# Altium schematic sheet TS5_MCU.SchDoc(TS5_MCU)
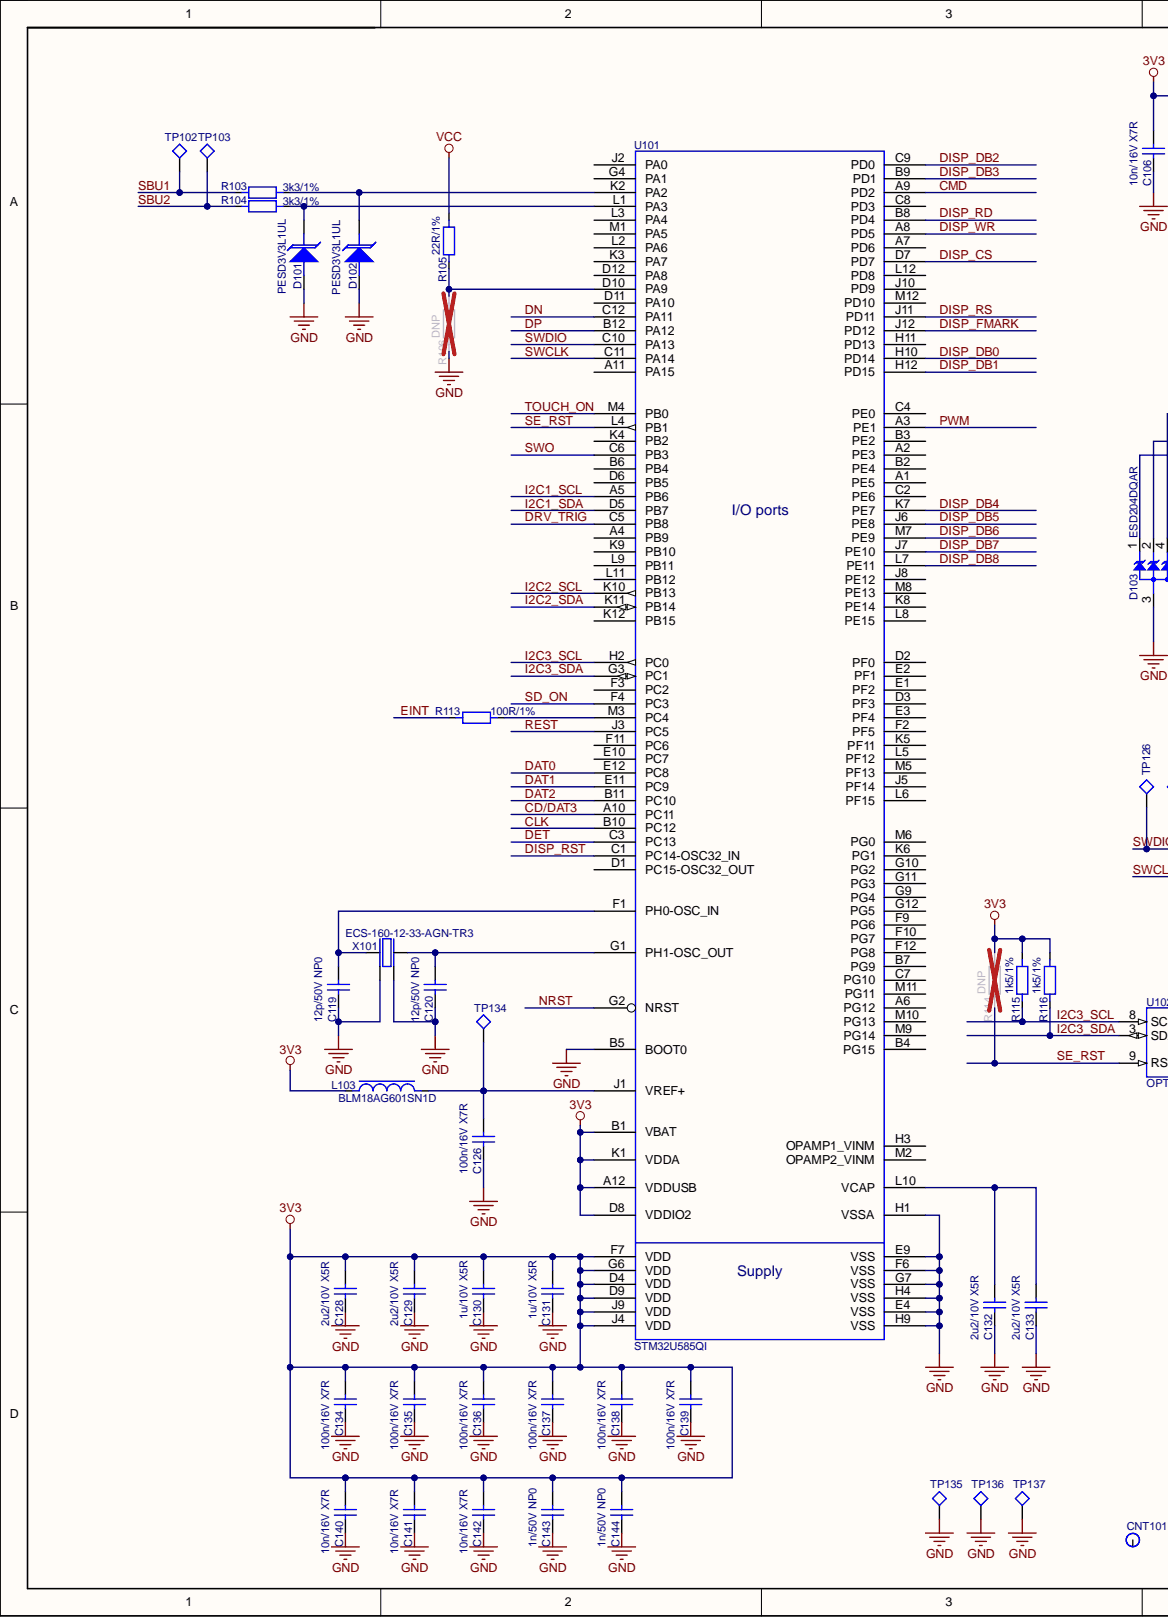
[diagram p0: TS5_MCU.SchDoc, left]
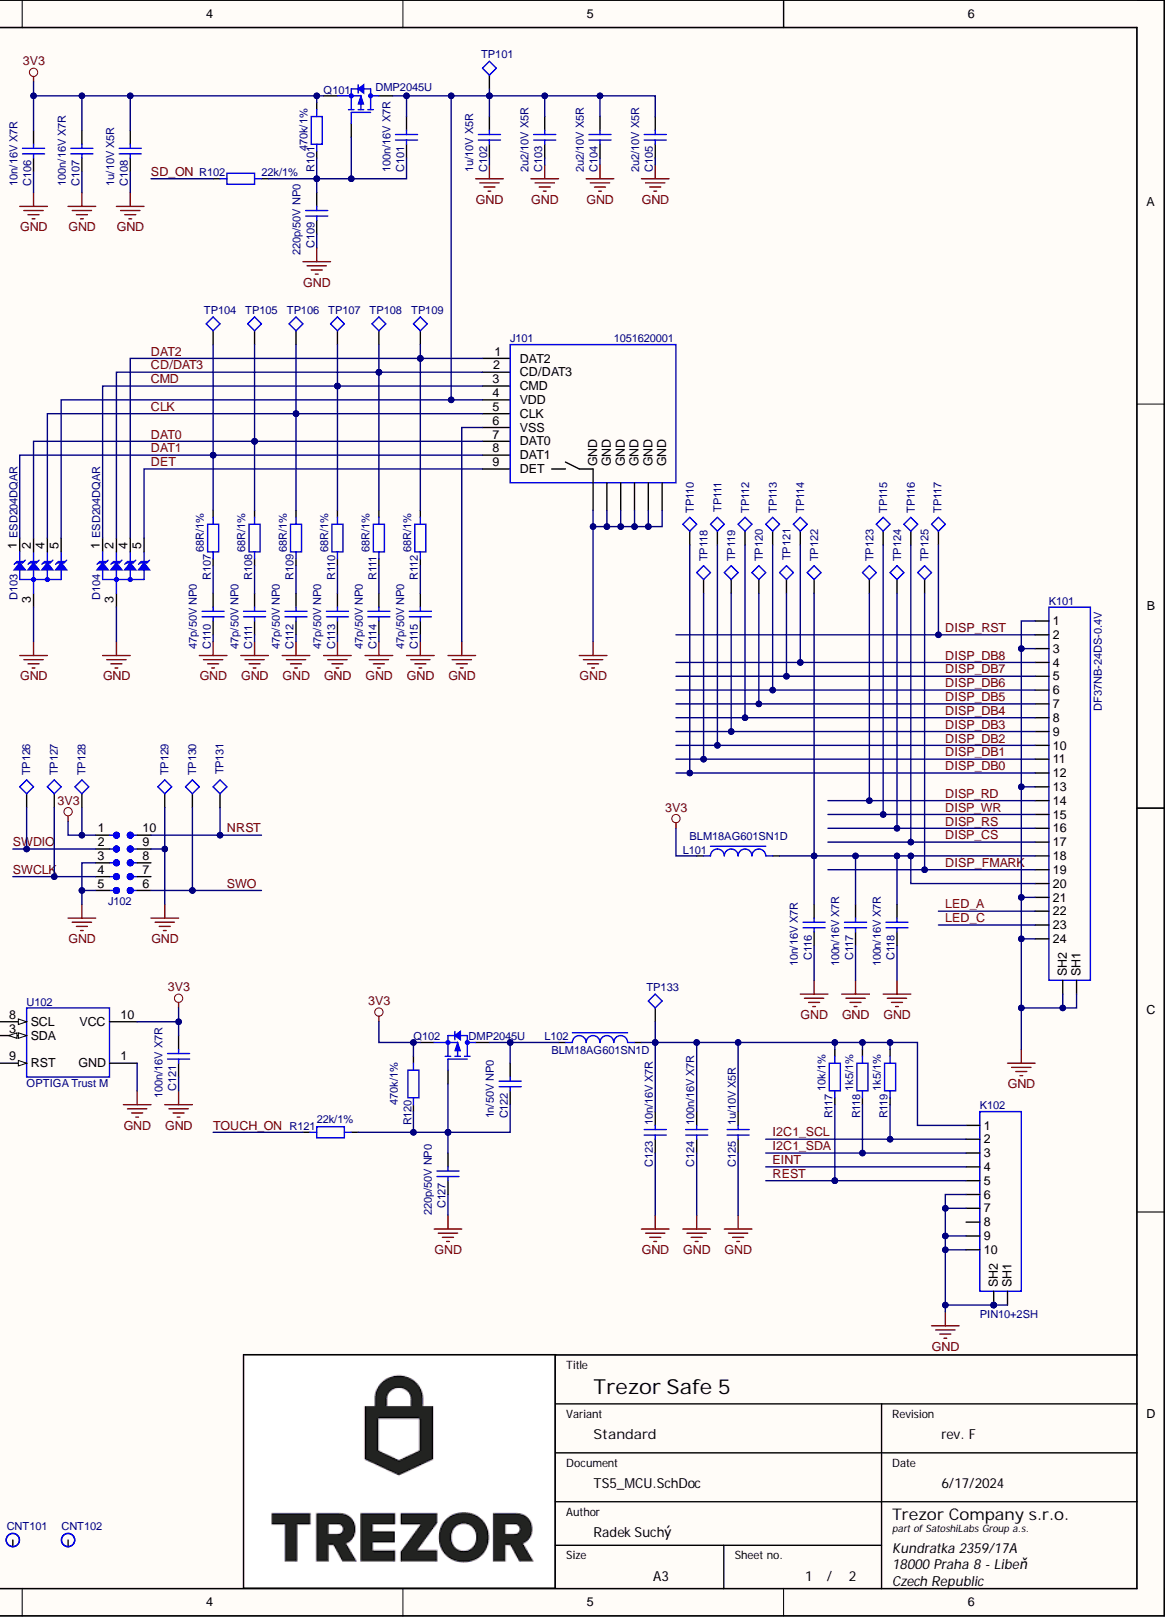
[diagram p1: TS5_MCU.SchDoc, right]

## Parts
C101  100n/16V X7R  [C_0402_B]
C102  1u/10V X5R  [C_0402_B]
C103  2u2/10V X5R  [C_0402_B]
C104  2u2/10V X5R  [C_0402_B]
C105  2u2/10V X5R  [C_0402_B]
C106  10n/16V X7R  [C_0402_B]
C107  100n/16V X7R  [C_0402_B]
C108  1u/10V X5R  [C_0402_B]
C109  220p/50V NP0  [C_0402_B]
C110  47p/50V NP0  [C_0402_B]
C111  47p/50V NP0  [C_0402_B]
C112  47p/50V NP0  [C_0402_B]
C113  47p/50V NP0  [C_0402_B]
C114  47p/50V NP0  [C_0402_B]
C115  47p/50V NP0  [C_0402_B]
C116  10n/16V X7R  [C_0402_B]
C117  100n/16V X7R  [C_0402_B]
C118  100n/16V X7R  [C_0402_B]
C119  12p/50V NP0  [C_0402_B]
C120  12p/50V NP0  [C_0402_B]
C121  100n/16V X7R  [C_0402_B]
C122  1n/50V NP0  [C_0402_B]
C123  10n/16V X7R  [C_0402_B]
C124  100n/16V X7R  [C_0402_B]
C125  1u/10V X5R  [C_0402_B]
C126  100n/16V X7R  [C_0402_B]
C127  220p/50V NP0  [C_0402_B]
C128  2u2/10V X5R  [C_0402_B]
C129  2u2/10V X5R  [C_0402_B]
C130  1u/10V X5R  [C_0402_B]
C131  1u/10V X5R  [C_0402_B]
C132  2u2/10V X5R  [C_0402_B]
C133  2u2/10V X5R  [C_0402_B]
C134  100n/16V X7R  [C_0402_B]
C135  100n/16V X7R  [C_0402_B]
C136  100n/16V X7R  [C_0402_B]
C137  100n/16V X7R  [C_0402_B]
C138  100n/16V X7R  [C_0402_B]
C139  100n/16V X7R  [C_0402_B]
C140  10n/16V X7R  [C_0402_B]
C141  10n/16V X7R  [C_0402_B]
C142  10n/16V X7R  [C_0402_B]
C143  1n/50V NP0  [C_0402_B]
C144  1n/50V NP0  [C_0402_B]
CNT101  (no value)  [Central_1.2mm]  (no BOM)
CNT102  (no value)  [Central_1.2mm]  (no BOM)
D101  PESD3V3L1UL  [SOD-882_B]
D102  PESD3V3L1UL  [SOD-882_B]
D103  ESD204DQAR  [USON-10_B(5pin)]
D104  ESD204DQAR  [USON-10_B(5pin)]
J101  1051620001  [1051620001]
J102  (no value)  [TAG_CONNECT_10_WITHOUT_HOLES]  (no BOM)
K101  DF37NB-24DS-0.4V  [DF37NB-24DS-0.4V]
K102  PIN10+2SH  [DF37NB-10DS-0.4V_mirrored]
L101  BLM18AG601SN1D  [L_0603_B]
L102  BLM18AG601SN1D  [L_0603_B]
L103  BLM18AG601SN1D  [L_0603_B]
Q101  DMP2045U  [SOT23-3_B]
Q102  DMP2045U  [SOT23-3_B]
R101  470k/1%  [R_0402_B]
R102  22k/1%  [R_0402_B]
R103  3k3/1%  [R_0603_B]
R104  3k3/1%  [R_0603_B]
R105  22R/1%  [R_0402_B]
R106  DNP  [R_0402_B]
R107  68R/1%  [R_0402_B]
R108  68R/1%  [R_0402_B]
R109  68R/1%  [R_0402_B]
R110  68R/1%  [R_0402_B]
R111  68R/1%  [R_0402_B]
R112  68R/1%  [R_0402_B]
R113  100R/1%  [R_0402_B]
R114  DNP  [R_0402_B]
R115  1k5/1%  [R_0402_B]
R116  1k5/1%  [R_0402_B]
R117  10k/1%  [R_0402_B]
R118  1k5/1%  [R_0402_B]
R119  1k5/1%  [R_0402_B]
R120  470k/1%  [R_0402_B]
R121  22k/1%  [R_0402_B]
TP101  Test_Point  [TP_1.5mm]  (value not drawn)  (no BOM)
TP102  Test_Point  [TP_1.5mm]  (value not drawn)  (no BOM)
TP103  Test_Point  [TP_1.5mm]  (value not drawn)  (no BOM)
TP104  Test_Point  [TP_1.5mm]  (value not drawn)  (no BOM)
TP105  Test_Point  [TP_1.5mm]  (value not drawn)  (no BOM)
TP106  Test_Point  [TP_1.5mm]  (value not drawn)  (no BOM)
TP107  Test_Point  [TP_1.5mm]  (value not drawn)  (no BOM)
TP108  Test_Point  [TP_1.5mm]  (value not drawn)  (no BOM)
TP109  Test_Point  [TP_1.5mm]  (value not drawn)  (no BOM)
TP110  Test_Point  [TP_1.0mm]  (value not drawn)  (no BOM)
TP111  Test_Point  [TP_1.0mm]  (value not drawn)  (no BOM)
TP112  Test_Point  [TP_1.0mm]  (value not drawn)  (no BOM)
TP113  Test_Point  [TP_1.0mm]  (value not drawn)  (no BOM)
TP114  Test_Point  [TP_1.0mm]  (value not drawn)  (no BOM)
TP115  Test_Point  [TP_1.0mm]  (value not drawn)  (no BOM)
TP116  Test_Point  [TP_1.0mm]  (value not drawn)  (no BOM)
TP117  Test_Point  [TP_1.0mm]  (value not drawn)  (no BOM)
TP118  Test_Point  [TP_1.0mm]  (value not drawn)  (no BOM)
TP119  Test_Point  [TP_1.0mm]  (value not drawn)  (no BOM)
TP120  Test_Point  [TP_1.0mm]  (value not drawn)  (no BOM)
TP121  Test_Point  [TP_1.0mm]  (value not drawn)  (no BOM)
TP122  Test_Point  [TP_1.5mm]  (value not drawn)  (no BOM)
TP123  Test_Point  [TP_1.0mm]  (value not drawn)  (no BOM)
TP124  Test_Point  [TP_1.0mm]  (value not drawn)  (no BOM)
TP125  Test_Point  [TP_1.0mm]  (value not drawn)  (no BOM)
TP126  Test_Point  [TP_1.5mm]  (value not drawn)  (no BOM)
TP127  Test_Point  [TP_1.5mm]  (value not drawn)  (no BOM)
TP128  Test_Point  [TP_1.5mm]  (value not drawn)  (no BOM)
TP129  Test_Point  [TP_1.5mm]  (value not drawn)  (no BOM)
TP130  Test_Point  [TP_1.5mm]  (value not drawn)  (no BOM)
TP131  Test_Point  [TP_1.5mm]  (value not drawn)  (no BOM)
TP133  Test_Point  [TP_1.5mm]  (value not drawn)  (no BOM)
TP134  Test_Point  [TP_1.5mm]  (value not drawn)  (no BOM)
TP135  Test_Point  [TP_1.5mm]  (value not drawn)  (no BOM)
TP136  Test_Point  [TP_1.5mm]  (value not drawn)  (no BOM)
TP137  Test_Point  [TP_1.5mm]  (value not drawn)  (no BOM)
U101  STM32U585QI  [UFBGA3-132_7X7_B]
U102  OPTIGA Trust M  [PG-USON-10-2_B]
X101  ECS-160-12-33-AGN-TR3  [X-4,3.2x2.5_B]

## Nets
3V3: C106.2 C107.2 C108.2 C121.2 C128.2 C129.2 C130.2 C131.2 C134.2 C135.2 C136.2 C137.2 C138.2 C139.2 C140.2 C141.2 C142.2 C143.2 C144.2 J102.1 L101.1 L103.1 Q101.2 Q102.2 R101.2 R114.1 R115.1 R116.1 R120.2 TP128.1 U101.A12(VDDUSB) U101.B1(VBAT) U101.D4(VDD) U101.D8(VDDIO2) U101.D9(VDD) U101.F7(VDD) U101.G6(VDD) U101.J4(VDD) U101.J9(VDD) U101.K1(VDDA) U102.10(VCC)
GND: C102.1 C103.1 C104.1 C105.1 C106.1 C107.1 C108.1 C109.1 C110.2 C111.2 C112.2 C113.2 C114.2 C115.2 C116.1 C117.1 C118.1 C119.1 C120.1 C121.1 C123.1 C124.1 C125.1 C126.1 C127.1 C128.1 C129.1 C130.1 C131.1 C132.1 C133.1 C134.1 C135.1 C136.1 C137.1 C138.1 C139.1 C140.1 C141.1 C142.1 C143.1 C144.1 D101.A D102.A D103.3 D104.3 J101.6(VSS) J101.G1(GND) J101.G2(GND) J101.G3(GND) J101.G4(GND) J101.G5(GND) J101.G6(GND) J102.3 J102.5 J102.9 K101.1 K101.3 K101.13 K101.21 K101.24 K101.SH1 K101.SH2 K102.6 K102.7 K102.9 K102.10 K102.SH1 K102.SH2 R106.2 TP129.1 TP135.1 TP136.1 TP137.1 U101.B5(BOOT0) U101.E4(VSS) U101.E9(VSS) U101.F6(VSS) U101.G7(VSS) U101.H1(VSSA) U101.H4(VSS) U101.H9(VSS) U102.1(GND) X101.2 X101.4
VCC: R105.2
CD/DAT3: D104.2 J101.2(CD/DAT3) R111.1 TP108.1 U101.A10(PC11)
CLK: D103.4 J101.5(CLK) R109.1 TP106.1 U101.B10(PC12)
CMD: D104.1 J101.3(CMD) R110.1 TP107.1 U101.A9(PD2)
DAT0: D103.2 J101.7(DAT0) R108.1 TP105.1 U101.E12(PC8)
DAT1: D103.1 J101.8(DAT1) R107.1 TP104.1 U101.E11(PC9)
DAT2: D104.4 J101.1(DAT2) R112.1 TP109.1 U101.B11(PC10)
DET: D104.5 J101.9(DET) U101.C3(PC13)
DISP_CS: K101.17 TP116.1 U101.D7(PD7)
DISP_DB0: K101.12 TP110.1 U101.H10(PD14)
DISP_DB1: K101.11 TP118.1 U101.H12(PD15)
DISP_DB2: K101.10 TP111.1 U101.C9(PD0)
DISP_DB3: K101.9 TP119.1 U101.B9(PD1)
DISP_DB4: K101.8 TP112.1 U101.K7(PE7)
DISP_DB5: K101.7 TP120.1 U101.J6(PE8)
DISP_DB6: K101.6 TP113.1 U101.M7(PE9)
DISP_DB7: K101.5 TP121.1 U101.J7(PE10)
DISP_DB8: K101.4 TP114.1 U101.L7(PE11)
DISP_FMARK: K101.19 TP125.1 U101.J12(PD12)
DISP_RD: K101.14 TP123.1 U101.B8(PD4)
DISP_RS: K101.16 TP124.1 U101.J11(PD11)
DISP_RST: K101.2 TP117.1 U101.C1(PC14-OSC32_IN)
DISP_WR: K101.15 TP115.1 U101.A8(PD5)
DN: U101.C12(PA11)
DP: U101.B12(PA12)
DRV_TRIG: U101.C5(PB8)
EINT: K102.4 R113.2
I2C1_SCL: K102.2 R119.2 U101.A5(PB6)
I2C1_SDA: K102.3 R118.2 U101.D5(PB7)
I2C2_SCL: U101.K10(PB13)
I2C2_SDA: U101.K11(PB14)
I2C3_SCL: R115.2 U101.H2(PC0) U102.8(SCL)
I2C3_SDA: R116.2 U101.G3(PC1) U102.3(SDA)
LED_A: K101.22
LED_C: K101.23
NRST: J102.10 TP131.1 U101.G2(NRST)
PWM: U101.A3(PE1)
REST: K102.5 R117.2 U101.J3(PC5)
SBU1: R103.1 TP102.1
SBU2: R104.1 TP103.1
SD_ON: R102.1 U101.F4(PC3)
SE_RST: R114.2 U101.L4(PB1) U102.9(RST)
SWCLK: J102.4 TP127.1 U101.C11(PA14)
SWDIO: J102.2 TP126.1 U101.C10(PA13)
SWO: J102.6 TP130.1 U101.C6(PB3)
TOUCH_ON: R121.1 U101.M4(PB0)
NetC101_1: C101.1 C109.2 Q101.1 R101.1 R102.2
NetC101_2: C101.2 C102.2 C103.2 C104.2 C105.2 D103.5 J101.4(VDD) Q101.3 TP101.1
NetC110_1: C110.1 R107.2
NetC111_1: C111.1 R108.2
NetC112_1: C112.1 R109.2
NetC113_1: C113.1 R110.2
NetC114_1: C114.1 R111.2
NetC115_1: C115.1 R112.2
NetC116_2: C116.2 C117.2 C118.2 K101.18 K101.20 L101.2 TP122.1
NetC119_2: C119.2 U101.F1(PH0-OSC_IN) X101.1
NetC120_2: C120.2 U101.G1(PH1-OSC_OUT) X101.3
NetC122_1: C122.1 C127.2 Q102.1 R120.1 R121.2
NetC122_2: C122.2 L102.1 Q102.3
NetC123_2: C123.2 C124.2 C125.2 K102.1 L102.2 R117.1 R118.1 R119.1 TP133.1
NetC126_2: C126.2 L103.2 TP134.1 U101.J1(VREF+)
NetC132_2: C132.2 C133.2 U101.L10(VCAP)
NetD101_C: D101.C R104.2 U101.L1(PA3)
NetD102_C: D102.C R103.2 U101.K2(PA2)
NetR105_1: R105.1 R106.1 U101.D10(PA9)
NetR113_1: R113.1 U101.M3(PC4)

Not connected: CNT101 1; CNT102 1; J102 7 8; K102 8; U101 A1(PE5) A2(PE3) A4(PB9) A6(PG12) A7(PD6) A11(PA15) B2(PE4) B3(PE2) B4(PG15) B6(PB4) B7(PG9) C2(PE6) C4(PE0) C7(PG10) C8(PD3) D1(PC15-OSC32_OUT) D2(PF0) D3(PF3) D6(PB5) D11(PA10) D12(PA8) E1(PF2) E2(PF1) E3(PF4) E10(PC7) F2(PF5) F3(PC2) F9(PG6) F10(PG7) F11(PC6) F12(PG8) G4(PA1) G9(PG4) G10(PG2) G11(PG3) G12(PG5) H3(OPAMP1_VINM) H11(PD13) J2(PA0) J5(PF14) J8(PE12) J10(PD9) K3(PA7) K4(PB2) K5(PF11) K6(PG1) K8(PE14) K9(PB10) K12(PB15) L2(PA6) L3(PA4) L5(PF12) L6(PF15) L8(PE15) L9(PB11) L11(PB12) L12(PD8) M1(PA5) M2(OPAMP2_VINM) M5(PF13) M6(PG0) M8(PE13) M9(PG14) M10(PG13) M11(PG11) M12(PD10)

## Library data of the parts
C101 C107 C117 C118 C121 C124 C126 C134-C139 = Capacitor: RegNo: SAT+CSM-109.00; Value: 100n; AddParam: /16V X7R; Component Kind: Standard; Library Name: RLC.SchLib; Package: C_0402_B; Technology: SMT
C102 C108 C125 C130 C131 = Capacitor: Man1: Taiyo Yuden; PN1: LMK105BJ105KVHF; RegNo: P+CSM-034.10; Value: 1u; AddParam: /10V X5R; Component Kind: Standard; Library Name: RLC.SchLib; Package: C_0402_B; Technology: SMT
C103-C105 C128 C129 C132 C133 = Capacitor: Man1: Taiyo Yuden; PN1: LMK105ABJ225KVHF; RegNo: P+CSM-025.54; Value: 2u2; AddParam: /10V X5R; Component Kind: Standard; Library Name: RLC.SchLib; Package: C_0402_B; Technology: SMT
C106 C116 C123 C140-C142 = Capacitor: RegNo: SAT+CSM-348.00; Value: 10n; AddParam: /16V X7R; Component Kind: Standard; Library Name: RLC.SchLib; Package: C_0402_B; Technology: SMT
C109 C127 = Capacitor: RegNo: SAT+CSM-328.00; Value: 220p; AddParam: /50V NP0; Component Kind: Standard; Library Name: RLC.SchLib; Package: C_0402_B; Technology: SMT
C110-C115 = Capacitor: RegNo: SAT+CSM-320.00; Value: 47p; AddParam: /50V NP0; Component Kind: Standard; Library Name: RLC.SchLib; Package: C_0402_B; Technology: SMT
C119 C120 = Capacitor: Value: 12p; AddParam: /50V NP0; Component Kind: Standard; Library Name: RLC.SchLib; Package: C_0402_B; Technology: SMT
C122 C143 C144 = Capacitor: RegNo: SAT+CSM-336.00; Value: 1n; AddParam: /50V NP0; Component Kind: Standard; Library Name: RLC.SchLib; Package: C_0402_B; Technology: SMT
CNT101 CNT102 = CENTRAL: Package: Central_1.2mm; Technology: SMT; Library Name: Fiducials.SchLib
D101 D102 = TVS_Uni: Component Kind: Standard; Library Name: Diode.SchLib; Package: SOD-882_B; Technology: SMT/THT; Value: PESD3V3L1UL
D103 D104 = CMP-004-000005-3: Electrostatic discharge protection, 4-Channel, 30kV, bidirectional  USON-10  -40°C +125°C; Manufacturer: Texas Instruments; Component Kind: Standard; Design Item ID: TVS_4-Lin_USON10; MPN: ESD204DQAR; Package: USON-10_B(5pin); Technology: SMT; Value: ESD204DQAR; Library Name: Trezor Company s.r.o.
J101 = MicroSD_1051620001: MicroSD CARD Holder; Man1: MOLEX; Component Kind: Standard; Library Name: Connector.SchLib; Package: 1051620001; Technology: SMT/THT; Value: 1051620001
J102 = Tag_Connect_10_pin: Library Name: TestPoint.SchLib; Package: TAG_CONNECT_10_WITHOUT_HOLES; Technology: SMT
K101 = PIN24+2SH: RegNo: P+KON-221.20; Component Kind: Standard; Library Name: Connector.SchLib; Package: DF37NB-24DS-0.4V; Technology: SMT; Value: DF37NB-24DS-0.4V
K102 = CMP-009-000003-3: 0.4mm Pitch, 0.98mm Stacking Height, FPC-to-Board Connectors  30V / 0,3A  -35°C +85°C; Manufacturer: Hirose Connector; MPN: DF37NB-10DS-0.4V; Value: DF37NB-10DS-0.4V; Component Kind: Standard; Design Item ID: PIN10+2SH; Package: DF37NB-10DS-0.4V_mirrored; Technology: SMT; Library Name: Trezor Company s.r.o.
L101 L102 = Ferrite_bead: PN1: BLM18AG102SN1D; RegNo: SAT+LT-095.00; Component Kind: Standard; Library Name: RLC.SchLib; Package: L_0603_B; Technology: SMT; Value: BLM18AG601SN1D
L103 = Ferrite_bead: RegNo: SAT+LT-095.00; Component Kind: Standard; Library Name: RLC.SchLib; Package: L_0603_B; Technology: SMT; Value: BLM18AG601SN1D
Q101 Q102 = PMOS_GSD: Man1: Diodes; RegNo: SAT+TR-066.21; Component Kind: Standard; Library Name: Transistor.SchLib; Package: SOT23-3_B; PN1: DMP2045U; Technology: SMT; Value: DMP2045U
R101 R120 = Resistor: AddParam: /1%; RegNo: SAT+RSM-268.00; Value: 470k; Component Kind: Standard; Library Name: RLC.SchLib; Package: R_0402_B; Technology: SMT
R102 R121 = Resistor: AddParam: /1%; RegNo: SAT+RSM-252.00; Value: 22k; Component Kind: Standard; Library Name: RLC.SchLib; Package: R_0402_B; Technology: SMT
R103 R104 = Resistor: AddParam: /1%; Value: 3k3; Component Kind: Standard; Library Name: RLC.SchLib; Package: R_0603_B; Technology: SMT
R105 = Resistor: AddParam: /1%; RegNo: SAT+RSM-216.00; Value: 22R; Component Kind: Standard; Library Name: RLC.SchLib; Package: R_0402_B; Technology: SMT
R106 R114 = Resistor: Component Kind: Standard; Library Name: RLC.SchLib; Package: R_0402_B; Technology: SMT; Value: DNP
R107-R112 = Resistor: AddParam: /1%; RegNo: SAT+RSM-222.00; Value: 68R; Component Kind: Standard; Library Name: RLC.SchLib; Package: R_0402_B; Technology: SMT
R113 = Resistor: AddParam: /1%; RegNo: SAT+RSM-224.00; Value: 100R; Component Kind: Standard; Library Name: RLC.SchLib; Package: R_0402_B; Technology: SMT
R115 R116 R118 R119 = Resistor: AddParam: /1%; RegNo: SAT+RSM-238.00; Value: 1k5; Component Kind: Standard; Library Name: RLC.SchLib; Package: R_0402_B; Technology: SMT
R117 = Resistor: AddParam: /1%; RegNo: SAT+RSM-248.00; Value: 10k; Component Kind: Standard; Library Name: RLC.SchLib; Package: R_0402_B; Technology: SMT
TP101-TP131 TP133-TP137 = CMP-008-000002-1: Design Item ID: Test_Point; Source: TS5_1.SCHLIB; Technology: SMT/THT; Library Name: Trezor Company s.r.o.
  TP101-TP109 TP122 TP126-TP131 TP133-TP137: Package: TP_1.5mm
  TP110-TP121 TP123-TP125: Package: TP_1.0mm
U101 = CMP-006-000004-4: Ultra low power 32-bit 160MHz Arm Cortex-M33 MCU with FPU, TrustZone, 2MB Flash; Manufacturer: STMicroelectronics; MPN: STM32U585QII6; Value: STM32U585QII6; Component Kind: Standard; Design Item ID: STM32U585QI; Package: UFBGA3-132_7X7_B; Source: TS5_1.SCHLIB; Technology: SMT; Library Name: Trezor Company s.r.o.
U102 = OPTIGA Trust M: Security controller; Man1: Infineon; PN1: SLS32AIA010MKUSON10XTMA2; Component Kind: Standard; Package: PG-USON-10-2_B; Technology: SMT; Value: OPTIGA Trust M; Library Name: IntegrCircuit.SchLib
X101 = Crystal_4pin: Man1: ECS; Man2: Abracon; PN2: ABM8AIG-16.000MHz-12-2Z-T; Component Kind: Standard; Library Name: Crystal_Oscillator.SchLib; Package: X-4,3.2x2.5_B; PN1: ECS-160-12-33-AGN-TR3; Technology: SMT; Value: ECS-160-12-33-AGN-TR3
